# Altium schematic sheet CVD01(Q2)-GS(VAL)260806CN-V2.0B2.0.SchDoc(CVD01(Q2)-GS(VAL)260806CN-V2.0B2.0)
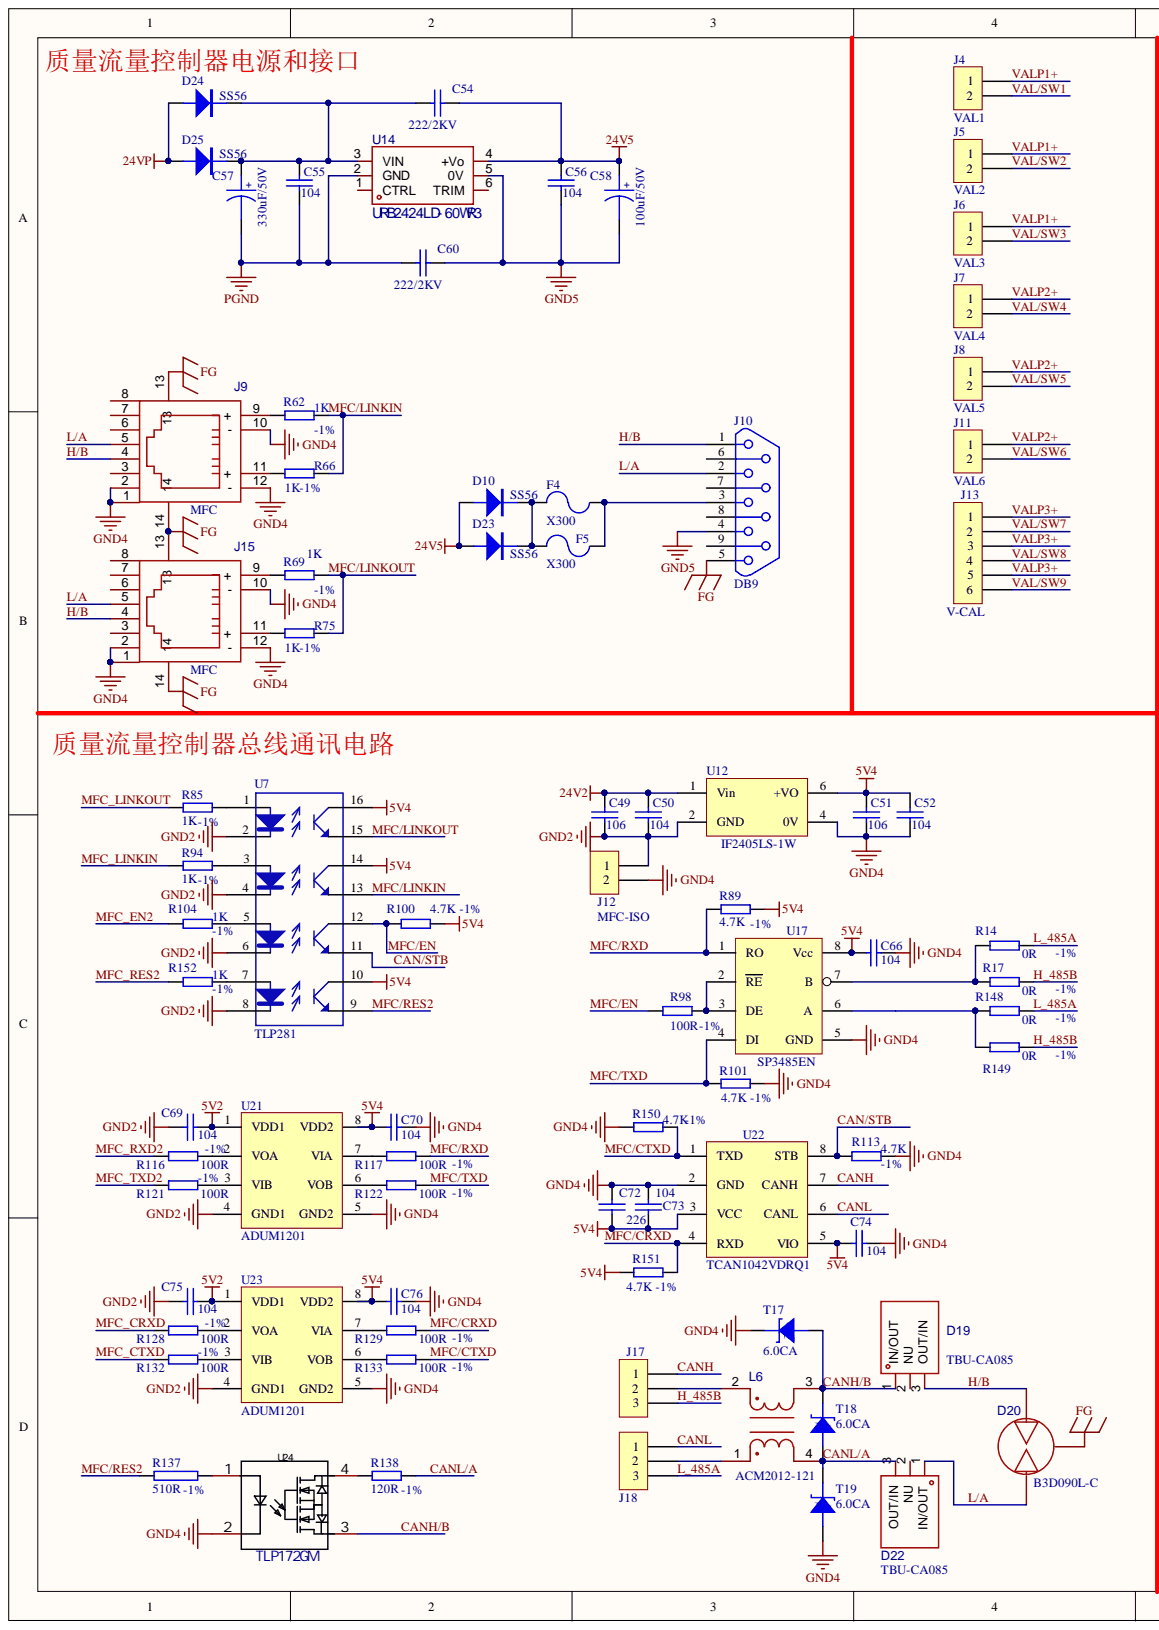
[diagram p0: CVD01, left]
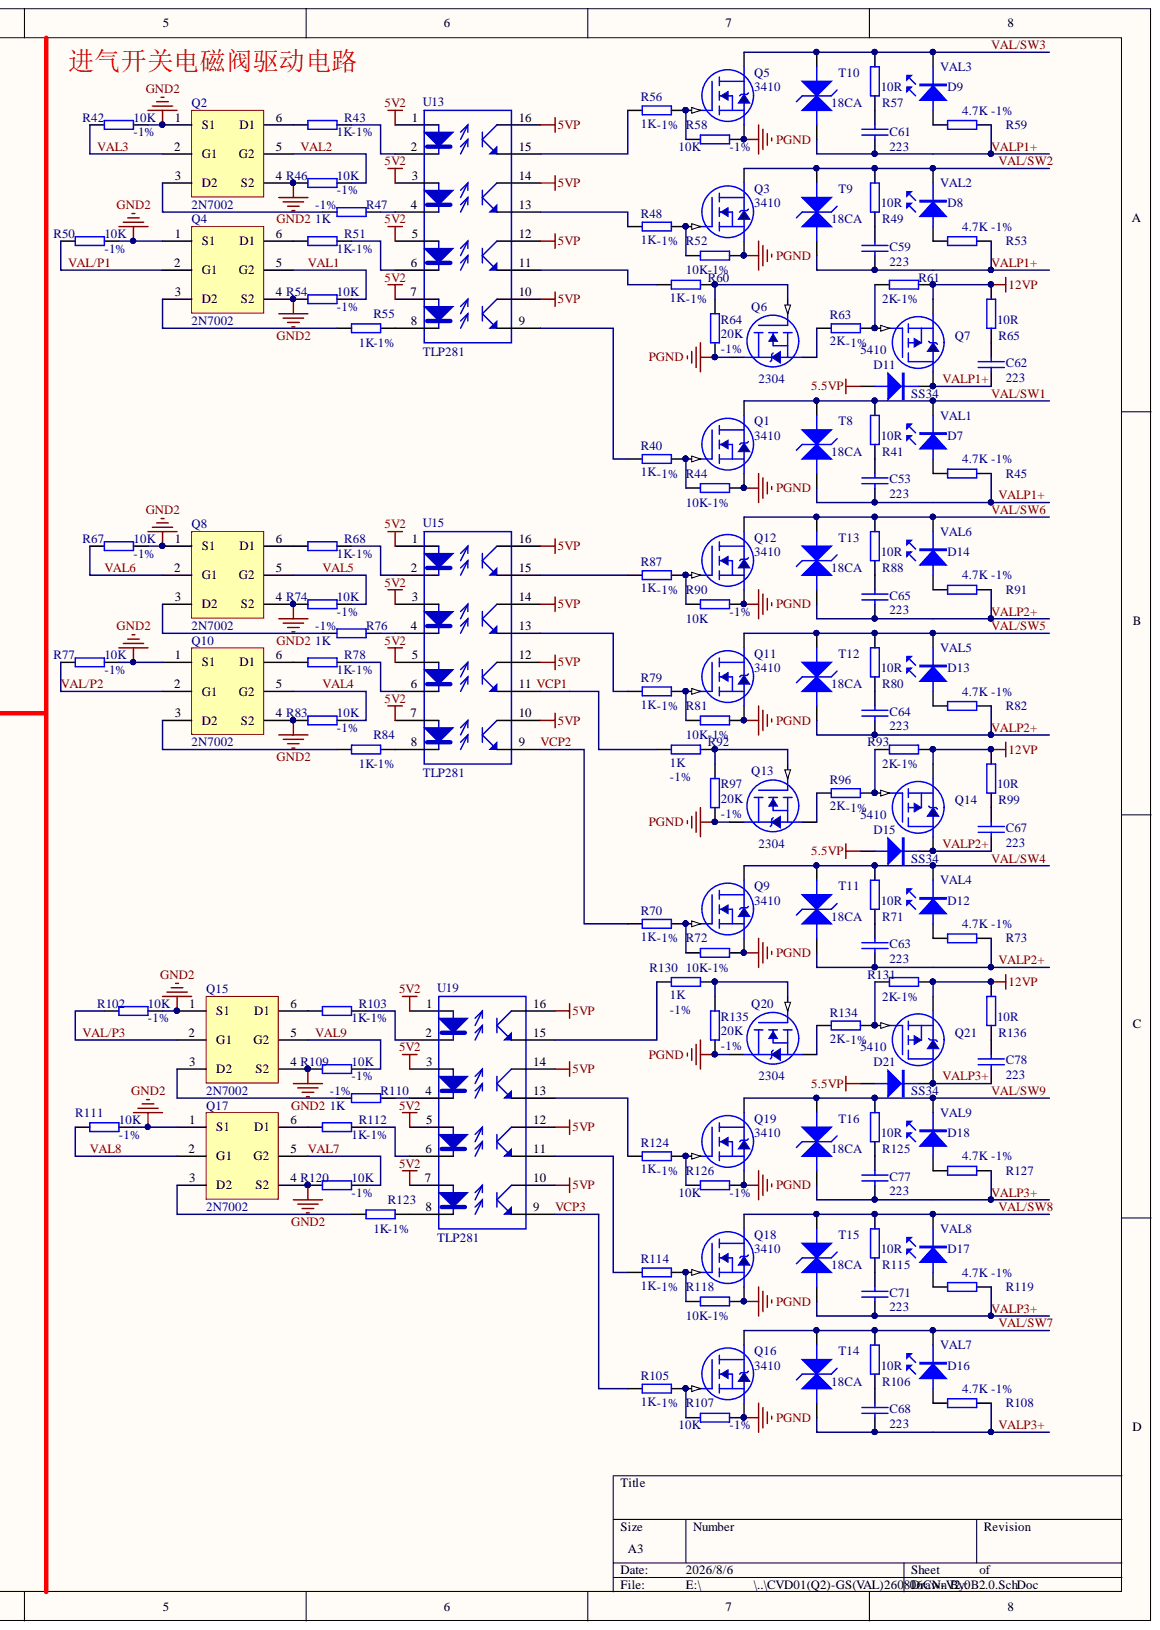
[diagram p1: CVD01, right]

## Parts
C49  106  [1206]
C50  104  [0603]
C51  106  [1206]
C52  104  [0603]
C53  223  [0603]
C54  222/2KV  [1206]
C55  104  [0603]
C56  104  [0603]
C57  330uF/50V  [6.3*7.7]
C58  100uF/50V  [6.3*7.7]
C59  223  [0603]
C60  222/2KV  [1206]
C61  223  [0603]
C62  223  [0603]
C63  223  [0603]
C64  223  [0603]
C65  223  [0603]
C66  104  [0603]
C67  223  [0603]
C68  223  [0603]
C69  104  [0603]
C70  104  [0603]
C71  223  [0603]
C72  226  [0603]
C73  104  [0603]
C74  104  [0603]
C75  104  [0603]
C76  104  [0603]
C77  223  [0603]
C78  223  [0603]
D7  VAL1  [0603(T)]
D8  VAL2  [0603(T)]
D9  VAL3  [0603(T)]
D10  SS56  [DO-214AC]
D11  SS34  [DO-214AC]
D12  VAL4  [0603(T)]
D13  VAL5  [0603(T)]
D14  VAL6  [0603(T)]
D15  SS34  [DO-214AC]
D16  VAL7  [0603(T)]
D17  VAL8  [0603(T)]
D18  VAL9  [0603(T)]
D19  TBU-CA085  [DIO-SMD_3P-L6.5-W4.0-BI]
D20  B3D090L-C  [GDT-SMD_3P-L7.3-W5.0-L]
D21  SS34  [DO-214AC]
D22  TBU-CA085  [DIO-SMD_3P-L6.5-W4.0-BI]
D23  SS56  [DO-214AC]
D24  SS56  [DO-214AC]
D25  SS56  [DO-214AC]
F4  X300  [1812]
F5  X300  [1812]
J4  VAL1  [VH4.00-2P(弯脚)]
J5  VAL2  [VH4.00-2P(弯脚)]
J6  VAL3  [VH4.00-2P(弯脚)]
J7  VAL4  [VH4.00-2P(弯脚)]
J8  VAL5  [VH4.00-2P(弯脚)]
J9  MFC  [RJ45-TH_HC-RJ45-5JA-1-1]
J10  DB9  [DB9]
J11  VAL6  [VH4.00-2P(弯脚)]
J12  MFC-ISO  [SIP-2]
J13  V-CAL  [VH4.00-6P(弯脚)]
J15  MFC  [RJ45-TH_HC-RJ45-5JA-1-1]
J17  CON3  [SIP-3]  (value not drawn)
J18  CON3  [SIP-3]  (value not drawn)
L6  ACM2012-121  [FILTER-SMD_0805-4P-L2.0-W1.2-BL]
Q1  3410  [SOT-252]
Q2  2N7002  [SOT-363]
Q3  3410  [SOT-252]
Q4  2N7002  [SOT-363]
Q5  3410  [SOT-252]
Q6  2304  [SOT-23]
Q7  5410  [SOT-252]
Q8  2N7002  [SOT-363]
Q9  3410  [SOT-252]
Q10  2N7002  [SOT-363]
Q11  3410  [SOT-252]
Q12  3410  [SOT-252]
Q13  2304  [SOT-23]
Q14  5410  [SOT-252]
Q15  2N7002  [SOT-363]
Q16  3410  [SOT-252]
Q17  2N7002  [SOT-363]
Q18  3410  [SOT-252]
Q19  3410  [SOT-252]
Q20  2304  [SOT-23]
Q21  5410  [SOT-252]
R14  0R  ERROR: -1%  [0603]
R17  0R  ERROR: -1%  [0603]
R40  1K  ERROR: -1%  [0603]
R41  10R  [0603]
R42  10K  ERROR: -1%  [0603]
R43  1K  ERROR: -1%  [0603]
R44  10K  ERROR: -1%  [0603]
R45  4.7K  ERROR: -1%  [0603]
R46  10K  ERROR: -1%  [0603]
R47  1K  ERROR: -1%  [0603]
R48  1K  ERROR: -1%  [0603]
R49  10R  [0603]
R50  10K  ERROR: -1%  [0603]
R51  1K  ERROR: -1%  [0603]
R52  10K  ERROR: -1%  [0603]
R53  4.7K  ERROR: -1%  [0603]
R54  10K  ERROR: -1%  [0603]
R55  1K  ERROR: -1%  [0603]
R56  1K  ERROR: -1%  [0603]
R57  10R  [0603]
R58  10K  ERROR: -1%  [0603]
R59  4.7K  ERROR: -1%  [0603]
R60  1K  ERROR: -1%  [0603]
R61  2K  ERROR: -1%  [0603]
R62  1K  ERROR: -1%  [0204]
R63  2K  ERROR: -1%  [0603]
R64  20K  ERROR: -1%  [0603]
R65  10R  [0603]
R66  1K  ERROR: -1%  [0204]
R67  10K  ERROR: -1%  [0603]
R68  1K  ERROR: -1%  [0603]
R69  1K  ERROR: -1%  [0204]
R70  1K  ERROR: -1%  [0603]
R71  10R  [0603]
R72  10K  ERROR: -1%  [0603]
R73  4.7K  ERROR: -1%  [0603]
R74  10K  ERROR: -1%  [0603]
R75  1K  ERROR: -1%  [0204]
R76  1K  ERROR: -1%  [0603]
R77  10K  ERROR: -1%  [0603]
R78  1K  ERROR: -1%  [0603]
R79  1K  ERROR: -1%  [0603]
R80  10R  [0603]
R81  10K  ERROR: -1%  [0603]
R82  4.7K  ERROR: -1%  [0603]
R83  10K  ERROR: -1%  [0603]
R84  1K  ERROR: -1%  [0603]
R85  1K  ERROR: -1%  [0603]
R87  1K  ERROR: -1%  [0603]
R88  10R  [0603]
R89  4.7K  ERROR: -1%  [0603]
R90  10K  ERROR: -1%  [0603]
R91  4.7K  ERROR: -1%  [0603]
R92  1K  ERROR: -1%  [0603]
R93  2K  ERROR: -1%  [0603]
R94  1K  ERROR: -1%  [0603]
R96  2K  ERROR: -1%  [0603]
R97  20K  ERROR: -1%  [0603]
R98  100R  ERROR: -1%  [0603]
R99  10R  [0603]
R100  4.7K  ERROR: -1%  [0603]
R101  4.7K  ERROR: -1%  [0603]
R102  10K  ERROR: -1%  [0603]
R103  1K  ERROR: -1%  [0603]
R104  1K  ERROR: -1%  [0603]
R105  1K  ERROR: -1%  [0603]
R106  10R  [0603]
R107  10K  ERROR: -1%  [0603]
R108  4.7K  ERROR: -1%  [0603]
R109  10K  ERROR: -1%  [0603]
R110  1K  ERROR: -1%  [0603]
R111  10K  ERROR: -1%  [0603]
R112  1K  ERROR: -1%  [0603]
R113  4.7K  ERROR: -1%  [0603]
R114  1K  ERROR: -1%  [0603]
R115  10R  [0603]
R116  100R  ERROR: -1%  [0603]
R117  100R  ERROR: -1%  [0603]
R118  10K  ERROR: -1%  [0603]
R119  4.7K  ERROR: -1%  [0603]
R120  10K  ERROR: -1%  [0603]
R121  100R  ERROR: -1%  [0603]
R122  100R  ERROR: -1%  [0603]
R123  1K  ERROR: -1%  [0603]
R124  1K  ERROR: -1%  [0603]
R125  10R  [0603]
R126  10K  ERROR: -1%  [0603]
R127  4.7K  ERROR: -1%  [0603]
R128  100R  ERROR: -1%  [0603]
R129  100R  ERROR: -1%  [0603]
R130  1K  ERROR: -1%  [0603]
R131  2K  ERROR: -1%  [0603]
R132  100R  ERROR: -1%  [0603]
R133  100R  ERROR: -1%  [0603]
R134  2K  ERROR: -1%  [0603]
R135  20K  ERROR: -1%  [0603]
R136  10R  [0603]
R137  510R  ERROR: -1%  [0603]
R138  120R  ERROR: -1%  [1206]
R148  0R  ERROR: -1%  [0603]
R149  0R  ERROR: -1%  [0603]
R150  4.7K  ERROR: -1%  [0603]
R151  4.7K  ERROR: -1%  [0603]
R152  1K  ERROR: -1%  [0603]
T8  18CA  [1812(T)]
T9  18CA  [1812(T)]
T10  18CA  [1812(T)]
T11  18CA  [1812(T)]
T12  18CA  [1812(T)]
T13  18CA  [1812(T)]
T14  18CA  [1812(T)]
T15  18CA  [1812(T)]
T16  18CA  [1812(T)]
T17  6.0CA  [SOD-123]
T18  6.0CA  [SOD-123]
T19  6.0CA  [SOD-123]
U7  TLP281  [SOJ-16]
U12  IF2405LS-1W  [IB_LS-1W]
U13  TLP281  [SOJ-16]
U14  URB2424LD-60WR3  [URB24XXLD-60WR3]
U15  TLP281  [SOJ-16]
U17  SP3485EN  [SO-8]
U19  TLP281  [SOJ-16]
U21  ADUM1201  [SO-8]
U22  TCAN1042VDRQ1  [SO-8]
U23  ADUM1201  [SO-8]
U24  TLP172GM  [SMD-4]

## Nets
5.5VP: D11.1 D15.1 D21.1
5V2: C69.2 C75.2 U13.1 U13.3 U13.5 U13.7 U15.1 U15.3 U15.5 U15.7 U19.1 U19.3 U19.5 U19.7 U21.1(VDD1) U23.1(VDD1)
5V4: C51.1 C52.1 C66.2 C70.1 C72.2 C73.2 C74.1 C76.1 R89.2 R100.2 R151.2 U7.10 U7.14 U7.16 U12.6(+VO) U17.8(Vcc) U21.8(VDD2) U22.3(VCC) U22.5(VIO) U23.8(VDD2)
5VP: U13.10 U13.12 U13.14 U13.16 U15.10 U15.12 U15.14 U15.16 U19.10 U19.12 U19.14 U19.16
12VP: Q7.3 Q14.3 Q21.3 R61.2 R65.2 R93.2 R99.2 R131.2 R136.2
24V2: C49.1 C50.1 U12.1(Vin)
24V5: C54.2 C56.1 C58.1 D10.1 D23.1 U14.4(+Vo)
24VP: D24.1 D25.1
FG: D20.2 J9.13 J9.14 J10.5 J15.13 J15.14
GND2: C49.2 C50.2 C69.1 C75.1 J12.1 Q2.1(S1) Q2.4(S2) Q4.1(S1) Q4.4(S2) Q8.1(S1) Q8.4(S2) Q10.1(S1) Q10.4(S2) Q15.1(S1) Q15.4(S2) Q17.1(S1) Q17.4(S2) R42.1 R46.2 R50.1 R54.2 R67.1 R74.2 R77.1 R83.2 R102.1 R109.2 R111.1 R120.2 U7.2 U7.4 U7.6 U7.8 U12.2(GND) U21.4(GND1) U23.4(GND1)
GND4: C51.2 C52.2 C66.1 C70.2 C72.1 C73.1 C74.2 C76.2 J9.1 J9.2 J9.10(-) J9.12(-) J12.2 J15.1 J15.2 J15.10(-) J15.12(-) R101.2 R113.2 R150.2 T17.2 T19.1 U12.4(0V) U17.5(GND) U21.5(GND2) U22.2(GND) U23.5(GND2) U24.2
GND5: C56.2 C58.2 C60.2 J10.4 U14.5(0V)
PGND: C55.2 C57.2 C60.1 Q1.3 Q3.3 Q5.3 Q6.2 Q9.3 Q11.3 Q12.3 Q13.2 Q16.3 Q18.3 Q19.3 Q20.2 R44.2 R52.2 R58.2 R64.1 R72.2 R81.2 R90.2 R97.1 R107.2 R118.2 R126.2 R135.1 U14.2(GND)
CAN/STB: R113.1 U7.11 U22.8(STB)
CANH: J17.1 U22.7(CANH)
CANH/B: D19.1 L6.3 T17.1 T18.2 U24.3
CANL: J18.1 U22.6(CANL)
CANL/A: D22.3 L6.4 R138.2 T18.1 T19.2
H/B: D19.3 D20.1 J9.4 J10.1 J15.4
H_485B: J17.3 R17.2 R149.2
L/A: D20.3 D22.1 J9.5 J10.2 J15.5
L_485A: J18.3 R14.2 R148.2
MFC/CRXD: R129.2 R151.1 U22.4(RXD)
MFC/CTXD: R133.2 R150.1 U22.1(TXD)
MFC/EN: R98.1 R100.1 U7.12
MFC/LINKIN: R62.1 R66.1 U7.13
MFC/LINKOUT: R69.1 R75.1 U7.15
MFC/RES2: R137.1 U7.9
MFC/RXD: R89.1 R117.2 U17.1(RO)
MFC/TXD: R101.1 R122.2 U17.4(DI)
MFC_CRXD: R128.1
MFC_CTXD: R132.1
MFC_EN2: R104.1
MFC_LINKIN: R94.1
MFC_LINKOUT: R85.1
MFC_RES2: R152.1
MFC_RXD2: R116.1
MFC_TXD2: R121.1
VAL1: Q4.5(G2) R54.1
VAL2: Q2.5(G2) R46.1
VAL3: Q2.2(G1) R42.2
VAL4: Q10.5(G2) R83.1
VAL5: Q8.5(G2) R74.1
VAL6: Q8.2(G1) R67.2
VAL7: Q17.5(G2) R120.1
VAL8: Q17.2(G1) R111.2
VAL9: Q15.5(G2) R109.1
VAL/P1: Q4.2(G1) R50.2
VAL/P2: Q10.2(G1) R77.2
VAL/P3: Q15.2(G1) R102.2
VAL/SW1: D7.2 J4.2 Q1.2 R41.2 T8.1
VAL/SW2: D8.2 J5.2 Q3.2 R49.2 T9.1
VAL/SW3: D9.2 J6.2 Q5.2 R57.2 T10.1
VAL/SW4: D12.2 J7.2 Q9.2 R71.2 T11.1
VAL/SW5: D13.2 J8.2 Q11.2 R80.2 T12.1
VAL/SW6: D14.2 J11.2 Q12.2 R88.2 T13.1
VAL/SW7: D16.2 J13.2 Q16.2 R106.2 T14.1
VAL/SW8: D17.2 J13.4 Q18.2 R115.2 T15.1
VAL/SW9: D18.2 J13.6 Q19.2 R125.2 T16.1
VALP1+: C53.2 C59.2 C61.2 C62.2 D11.2 J4.1 J5.1 J6.1 Q7.2 R45.1 R53.1 R59.1 T8.2 T9.2 T10.2
VALP2+: C63.2 C64.2 C65.2 C67.2 D15.2 J7.1 J8.1 J11.1 Q14.2 R73.1 R82.1 R91.1 T11.2 T12.2 T13.2
VALP3+: C68.2 C71.2 C77.2 C78.2 D21.2 J13.1 J13.3 J13.5 Q21.2 R108.1 R119.1 R127.1 T14.2 T15.2 T16.2
VCP2: R70.1 U15.9
VCP3: R105.1 U19.9
NetC53_1: C53.1 R41.1
NetC54_1: C54.1 C55.1 C57.1 D24.2 D25.2 U14.3(VIN)
NetC59_1: C59.1 R49.1
NetC61_1: C61.1 R57.1
NetC62_1: C62.1 R65.1
NetC63_1: C63.1 R71.1
NetC64_1: C64.1 R80.1
NetC65_1: C65.1 R88.1
NetC67_1: C67.1 R99.1
NetC68_1: C68.1 R106.1
NetC71_1: C71.1 R115.1
NetC77_1: C77.1 R125.1
NetC78_1: C78.1 R136.1
NetD7_1: D7.1 R45.2
NetD8_1: D8.1 R53.2
NetD9_1: D9.1 R59.2
NetD10_2: D10.2 D23.2 F4.2 F5.2
NetD12_1: D12.1 R73.2
NetD13_1: D13.1 R82.2
NetD14_1: D14.1 R91.2
NetD16_1: D16.1 R108.2
NetD17_1: D17.1 R119.2
NetD18_1: D18.1 R127.2
NetF4_1: F4.1 F5.1 J10.3
NetJ9_9: J9.9(+) R62.2
NetJ9_11: J9.11(+) R66.2
NetJ15_9: J15.9(+) R69.2
NetJ15_11: J15.11(+) R75.2
NetJ17_2: J17.2 L6.2
NetJ18_2: J18.2 L6.1
NetQ1_1: Q1.1 R40.2 R44.1
NetQ2_3: Q2.3(D2) R47.1
NetQ2_6: Q2.6(D1) R43.1
NetQ3_1: Q3.1 R48.2 R52.1
NetQ4_3: Q4.3(D2) R55.1
NetQ4_6: Q4.6(D1) R51.1
NetQ5_1: Q5.1 R56.2 R58.1
NetQ6_1: Q6.1 R60.2 R64.2
NetQ6_3: Q6.3 R63.1
NetQ7_1: Q7.1 R61.1 R63.2
NetQ8_3: Q8.3(D2) R76.1
NetQ8_6: Q8.6(D1) R68.1
NetQ9_1: Q9.1 R70.2 R72.1
NetQ10_3: Q10.3(D2) R84.1
NetQ10_6: Q10.6(D1) R78.1
NetQ11_1: Q11.1 R79.2 R81.1
NetQ12_1: Q12.1 R87.2 R90.1
NetQ13_1: Q13.1 R92.2 R97.2
NetQ13_3: Q13.3 R96.1
NetQ14_1: Q14.1 R93.1 R96.2
NetQ15_3: Q15.3(D2) R110.1
NetQ15_6: Q15.6(D1) R103.1
NetQ16_1: Q16.1 R105.2 R107.1
NetQ17_3: Q17.3(D2) R123.1
NetQ17_6: Q17.6(D1) R112.1
NetQ18_1: Q18.1 R114.2 R118.1
NetQ19_1: Q19.1 R124.2 R126.1
NetQ20_1: Q20.1 R130.2 R135.2
NetQ20_3: Q20.3 R134.1
NetQ21_1: Q21.1 R131.1 R134.2
NetR14_1: R14.1 R17.1 U17.7(B)
NetR40_1: R40.1 U13.9
NetR43_2: R43.2 U13.2
NetR47_2: R47.2 U13.4
NetR48_1: R48.1 U13.13
NetR51_2: R51.2 U13.6
NetR55_2: R55.2 U13.8
NetR56_1: R56.1 U13.15
NetR60_1: R60.1 U13.11
NetR68_2: R68.2 U15.2
NetR76_2: R76.2 U15.4
NetR78_2: R78.2 U15.6
NetR79_1: R79.1 U15.13
NetR84_2: R84.2 U15.8
NetR85_2: R85.2 U7.1
NetR87_1: R87.1 U15.15
NetR92_1: R92.1 U15.11
NetR94_2: R94.2 U7.3
NetR98_2: R98.2 U17.2(RE) U17.3(DE)
NetR103_2: R103.2 U19.2
NetR104_2: R104.2 U7.5
NetR110_2: R110.2 U19.4
NetR112_2: R112.2 U19.6
NetR114_1: R114.1 U19.11
NetR116_2: R116.2 U21.2(VOA)
NetR117_1: R117.1 U21.7(VIA)
NetR121_2: R121.2 U21.3(VIB)
NetR122_1: R122.1 U21.6(VOB)
NetR123_2: R123.2 U19.8
NetR124_1: R124.1 U19.13
NetR128_2: R128.2 U23.2(VOA)
NetR129_1: R129.1 U23.7(VIA)
NetR130_1: R130.1 U19.15
NetR132_2: R132.2 U23.3(VIB)
NetR133_1: R133.1 U23.6(VOB)
NetR137_2: R137.2 U24.1
NetR138_1: R138.1 U24.4
NetR148_1: R148.1 R149.1 U17.6(A)
NetR152_2: R152.2 U7.7
VCP1:

Not connected: D19 2; D22 2; J9 3 6 7 8; J10 6 7 8 9; J15 3 6 7 8; U14 1(CTRL) 6(TRIM)

## Library data of the parts
C49 C51 = CAP: 无极性电容; NAME: 贴片电容; MODEL: 1206-106; Component Kind: Standard; Library Name: ChangAi-Library-3.00-191203-ZC.SchLib
C50 C52 C55 C56 C66 C69 C70 C73-C76 = CAP: 无极性电容; NAME: 贴片电容; MODEL: 0603-104; Component Kind: Standard
  C50 C52 C55 C56: Library Name: ChangAi-Library-3.00-191203-ZC.SchLib
  C66 C69 C70 C73-C76: Library Name: ChangAi-Library-4.00-200110-ZC.IntLib
C53 C59 C61-C65 C67 C68 C71 C77 C78 = CAP: 无极性电容; NAME: 贴片电容; MODEL: 0603-223; Component Kind: Standard; Library Name: ChangAi-Library-2.00-181023-ZZM.IntLib
C54 C60 = CAP: 无极性电容; NAME: 贴片电容; MODEL: 1206-222/2KV; PRICE: 0.23; Component Kind: Standard; Library Name: ChangAi-Library-4.00-200110-ZC.IntLib
C57 C58 = CAP+: 电解电容; NAME: 贴片电解电容; PRICE: 0.153; Component Kind: Standard; Library Name: ChangAi-Library-2.00-181023-ZZM.IntLib
  C57: MODEL: 330uF/50V
  C58: MODEL: 100uF/50V
C72 = CAP: 无极性电容; NAME: 贴片电容; MODEL: 0603-226; Component Kind: Standard; Library Name: ChangAi-Library-4.00-200110-ZC.IntLib
D7-D9 D12-D14 D16-D18 = LED: 发光二极管; NAME: 贴片发光二极管; MODEL: NCD0603R1红色; PRICE: 0.028; Component Kind: Standard; Library Name: ChangAi-Library-3.00-191203-ZC.SchLib
D10 D23-D25 = DIODE: 贴片二极管; PRICE: 0.2; NAME: 贴片二极管; MODEL: SS56; Component Kind: Standard; Library Name: ChangAi-Library-4.00-200108-ZC.SchLib
D11 D15 D21 = DIODE: 贴片二极管; MODEL: 1N5822(SS34); PRICE: 0.18; NAME: 贴片二极管; Component Kind: Standard; Library Name: ChangAi-Library-3.00-191203-ZC.SchLib
D19 D22 = TBU-CA085-100-WH: NAME: 过流保护器; PRICE: 4.9; MODEL: TBU-CA085-100-WH; Component Kind: Standard
D20 = B3D090L-C: NAME: 气体放电管; PRICE: 2; MODEL: B3D090L-C; Component Kind: Standard
F4 F5 = FUSE: 自恢复保险管; MODEL: 1812X300 (SMD1812P300SLR/24); PRICE: 0.45; NAME: 自恢复保险管; Component Kind: Standard; Library Name: ChangAi-Library-2.00-181023-ZZM.IntLib
J4-J8 J11 = CON2: NAME: 白色接插件座; MODEL: VH3.96-2P  弯脚; Component Kind: Standard; Library Name: ChangAi-Library-3.00-191203-ZC.SchLib
J9 J15 = HC-RJ45-5JA-1-1: NAME: 以太网连接器; MODEL: HC-RJ45-5JA-1-1; Component Kind: Standard
J10 = DB9: 焊接式9P; NAME: DP头子; PRICE: 0.782; MODEL: 焊接式9P; Component Kind: Standard; Library Name: ChangAi-Library-3.00-191203-ZC.SchLib
J12 = CON2: NAME: 单排插针; MODEL: 1*2-11MM; Component Kind: Standard; Library Name: ChangAi-Library-3.00-191203-ZC.SchLib
J13 = CON6: NAME: 白色接件座; MODEL: VH3.96-6P  弯脚; Component Kind: Standard; Library Name: ChangAi-Library-3.00-191203-ZC.SchLib
J17 J18 = CON3: NAME: 单排插针; MODEL: 1*3P-11MM; Component Kind: Standard; Library Name: ChangAi-Library-3.00-191203-ZC.SchLib
L6 = ACM2012-121-2P-T002: NAME: 贴片共模电感; PRICE: 0.7; MODEL: ACM2012-121-2P-T002; Component Kind: Standard
Q1 Q3 Q5 Q9 Q11 Q12 Q16 Q18 Q19 = NMOS: NAME: 贴片场效应管; MODEL: IRFR3410TRLPBF; Description: NMOS; Component Kind: Standard; Library Name: ChangAi-Library-3.00-191203-ZC.SchLib
Q2 Q4 Q8 Q10 Q15 Q17 = 2N7002DW-7-F: 双通道NMOS; NAME: 贴片集成块; MODEL: 2N7002DW-7-F; Component Kind: Standard; Library Name: ChangAi-Library-3.00-191203-ZC.SchLib
Q6 Q13 Q20 = NMOS: NAME: 贴片场效应管; MODEL: SI2304BDS; Description: NMOS; Component Kind: Standard; Library Name: ChangAi-Library-3.00-191203-ZC.SchLib
Q7 Q14 Q21 = PMOS: NAME: 贴片场效应管; MODEL: IRFR5410TRLPBF; Description: PMOS; Component Kind: Standard; Library Name: ChangAi-Library-2.00-181019-ZZM.SchLib
R14 R17 R148 R149 = RES: 1%精度; NAME: 贴片电阻; MODEL: 0603-0R; Component Kind: Standard; Library Name: ChangAi-Library-4.00-200110-ZC.IntLib
R40 R43 R47 R48 R51 R55 R56 R60 R68 R70 R76 R78 R79 R84 R85 R87 R92 R94 R103-R105 R110 R112 R114 R123 R124 R130 R152 = RES: 1%精度; NAME: 贴片电阻; MODEL: 0603-1K; Component Kind: Standard
  R40 R48 R56 R60 R70 R79 R87 R92 R105 R114 R124 R130: Library Name: ChangAi-Library-3.00-191203-ZC.SchLib
  R43 R47 R51 R55 R68 R76 R78 R84 R85 R94 R103 R104 R110 R112 R123 R152: Library Name: ChangAi-Library-4.00-200110-ZC.IntLib
R41 R49 R57 R65 R71 R80 R88 R99 R106 R115 R125 R136 = RES: 1%精度; NAME: 贴片电阻; MODEL: 0603-10R; Component Kind: Standard; Library Name: ChangAi-Library-4.00-200110-ZC.IntLib
R42 R44 R46 R50 R52 R54 R58 R67 R72 R74 R77 R81 R83 R90 R102 R107 R109 R111 R118 R120 R126 = RES: 1%精度; NAME: 贴片电阻; MODEL: 0603-10K; Component Kind: Standard; Library Name: ChangAi-Library-3.00-191203-ZC.SchLib
R45 R53 R59 R73 R82 R89 R91 R100 R101 R108 R113 R119 R127 R150 R151 = RES: 1%精度; NAME: 贴片电阻; MODEL: 0603-4.7K; Component Kind: Standard; Library Name: ChangAi-Library-4.00-200110-ZC.IntLib
R61 R63 R93 R96 R131 R134 = RES: 1%精度; NAME: 贴片电阻; MODEL: 0603-2K; Component Kind: Standard; Library Name: ChangAi-Library-3.00-191203-ZC.SchLib
R62 R66 R69 R75 = RES: 1%精度; NAME: 贴片功率电阻; MODEL: 0204-1K; Component Kind: Standard; Library Name: ChangAi-Library-4.00-200110-ZC.IntLib
R64 R97 R135 = RES: 1%精度; NAME: 贴片电阻; MODEL: 0603-20K; Component Kind: Standard; Library Name: ChangAi-Library-3.00-191203-ZC.SchLib
R98 R116 R117 R121 R122 R128 R129 R132 R133 = RES: 1%精度; NAME: 贴片电阻; MODEL: 0603-100R; Component Kind: Standard; Library Name: ChangAi-Library-4.00-200110-ZC.IntLib
R137 = RES1: 5%精度; NAME: 贴片电阻; MODEL: 0603-510R; Component Kind: Standard
R138 = RES: 1%精度; NAME: 贴片电阻; MODEL: 1206-120R; Component Kind: Standard; Library Name: ChangAi-Library-3.00-191203-ZC.SchLib
T8-T16 = TVS: NAME: TVS管; MODEL: P6SMB18CA (SMBJ18CA); Component Kind: Standard; Library Name: ChangAi-Library-3.00-191203-ZC.SchLib
T17-T19 = ZENER: TVS管; MODEL: PMF6.0CA; PRICE: 0.15; NAME: TVS管; Component Kind: Standard; Library Name: ChangAi-Library-4.00-200110-ZC.IntLib
U7 U13 U15 U19 = TLP281-4GB: 贴片光耦; NAME: 贴片光耦; MODEL: TLP281-4GB; Component Kind: Standard; Library Name: ChangAi-Library-3.00-191203-ZC.SchLib
U12 = IBXXXXLS-1W: DC模块; PRICE: 10; NAME: DC模块; MODEL: IF2405LS-1W; Component Kind: Standard; Library Name: ChangAi-Library-3.00-191203-ZC.SchLib
U14 = urb2424ld-60wr3: NAME: DCDC电源模块; PRICE: 162; MODEL: URB2424LD-60WR3; Component Kind: Standard
U17 = SP3485EN: 串口转485; NAME: 贴片集成块; PRICE: 1.45; MODEL: SP3485EN; Component Kind: Standard; Library Name: ChangAi-Library-4.00-200110-ZC.IntLib
U21 U23 = ADUM1201ARZ: 磁耦; NAME: 贴片集成块; PRICE: 2.5; MODEL: ADUM1201ARZ; Component Kind: Standard; Library Name: ChangAi-Library-4.00-200110-ZC.IntLib
U22 = TCAN1042VDRQ1: 串口转CAN; NAME: 贴片集成块; PRICE: 11.5; MODEL: TCAN1042VDRQ1; Component Kind: Standard; Library Name: ChangAi-Library-3.00-191203-ZC.SchLib
U24 = TLP172GM(TPL,E(O: NAME: 贴片集成块; PRICE: 2; MODEL: TLP172GM; Component Kind: Standard
